# Altium schematic sheet Security_module_v2_sheet.SchDoc
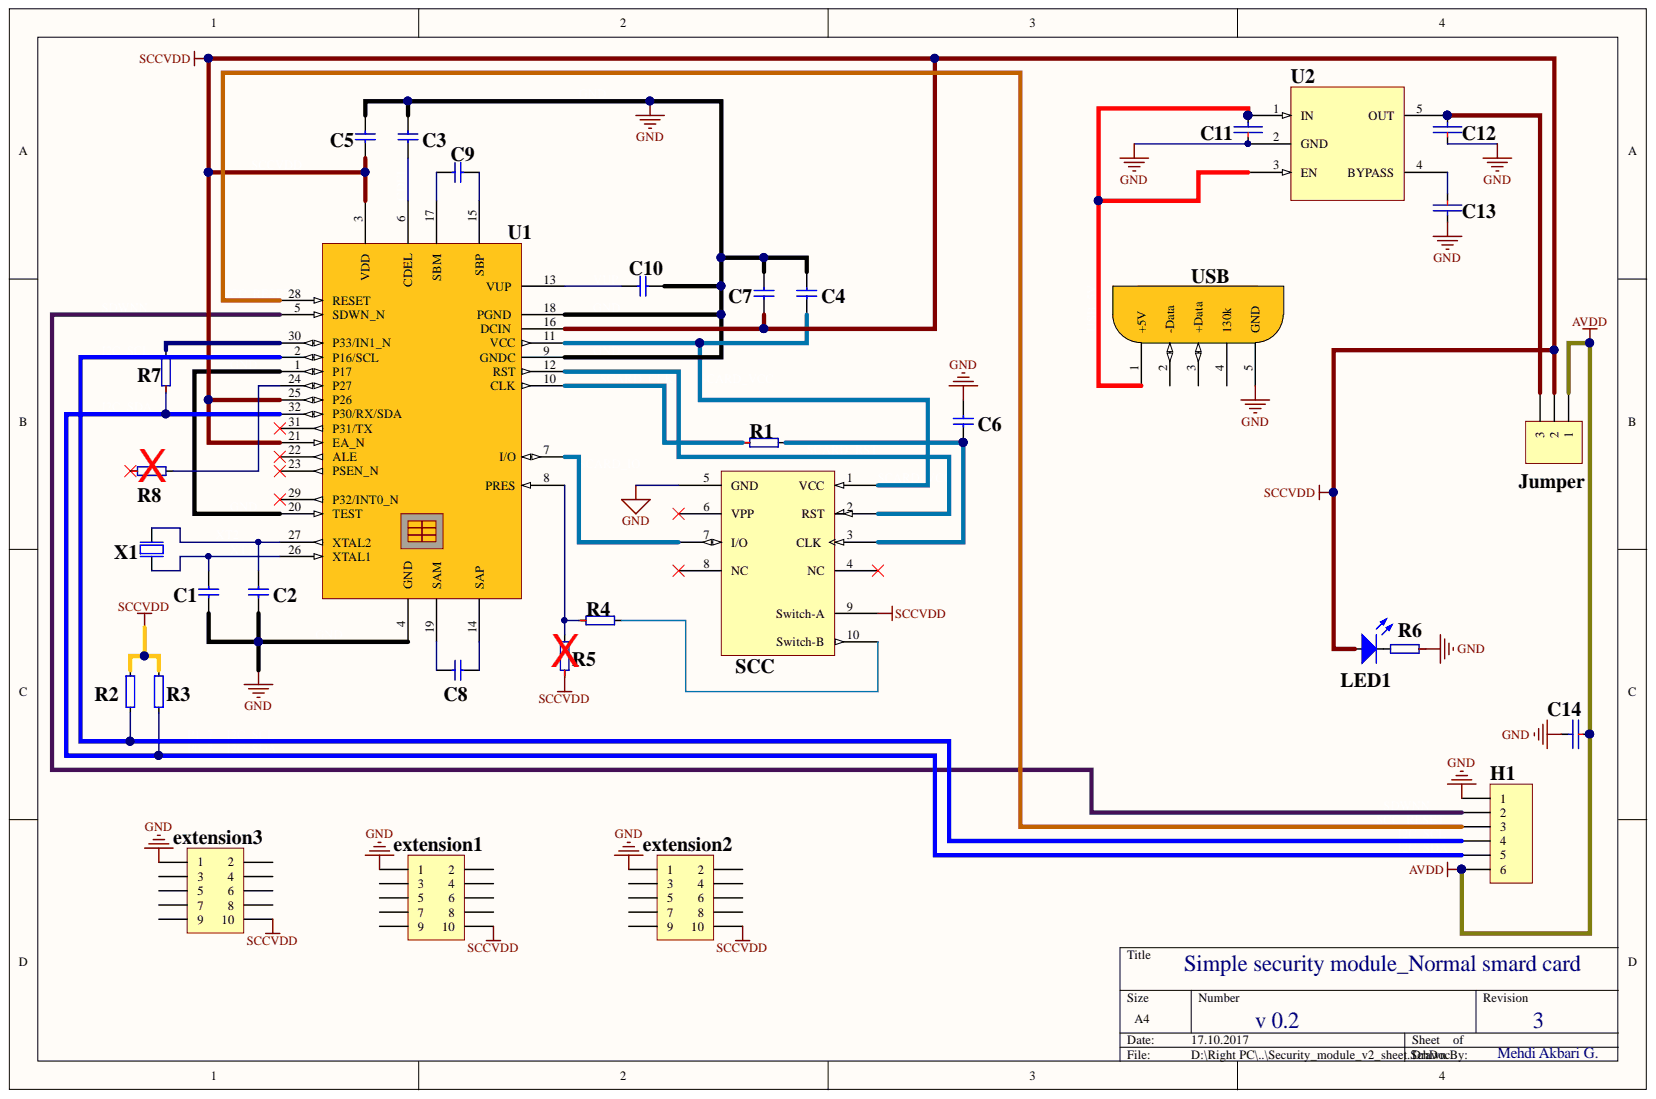
[diagram p0: Security_module_v2_sheet.SchDoc]

## Parts
C1  22pF  (value not drawn)
C2  22pF  (value not drawn)
C3  22nF  (value not drawn)
C4  220nF  (value not drawn)
C5  100nF  (value not drawn)
C6  33pF  (value not drawn)
C7  100nF  (value not drawn)
C8  220nF  (value not drawn)
C9  220nF  (value not drawn)
C10  220nF  (value not drawn)
C11  C_10U_0603_X5R_K_10  [CAP_IMPERIAL_0603]  (value not drawn)
C12  C_4U7_0603_X5R_K_6  [CAP_IMPERIAL_0603]  (value not drawn)
C13  C_10U_0603_X5R_K_10  [CAP_IMPERIAL_0603]  (value not drawn)
C14  C_10U_0603_X5R_K_10  [CAP_IMPERIAL_0603]  (value not drawn)
extension1  MHDR2X5  [extension 2X5]  (value not drawn)  (no BOM)
extension2  MHDR2X5  [extension 2X5]  (value not drawn)  (no BOM)
extension3  MHDR2X5  [extension 2X5]  (value not drawn)  (no BOM)
H1  Header  [HDR1X6]  (value not drawn)
Jumper  Jumper  [HDR1X3]
LED1  BLUE_LED_0603_2.1V_10mA_6mcd  [LED_IMPERIAL_0603_BLUE]  (value not drawn)
R1  R_100_0603_F  [RES_IMPERIAL_0603]  (value not drawn)
R2  10k  (value not drawn)
R3  10k  [0402]  (value not drawn)
R4  R_100_0603_F  [RES_IMPERIAL_0603]  (value not drawn)
R5  R_0_0603_F  [RES_IMPERIAL_0603]  (value not drawn)  (no BOM)
R6  R_1K_0603_F  [RES_IMPERIAL_0603]  (value not drawn)
R7  R_0_0603_F  [RES_IMPERIAL_0603]  (value not drawn)
R8  R_0_0603_F  [RES_IMPERIAL_0603]  (value not drawn)  (no BOM)
SCC  Smart Card Connector  [Big_smart_card_connector]  (value not drawn)
U1  TDA8029HL  [SOT358_L]  (value not drawn)
U2  LP3985IM5-3.3-NOPB  [SOT23-5AN]  (value not drawn)
USB  Micro-USB Receptacle  [M105017-0001]  (value not drawn)
X1  14.7456MHz  [BT-XTAL_3225]  (value not drawn)

## Nets
AVDD: C14.1 H1.6 Jumper.1
CDEL: C3.1 U1.6(CDEL)
GND: C1.1 C2.1 C3.2 C4.2 C5.2 C6.1 C7.2 C10.1 C11.1 C12.1 C13.2 C14.2 extension1.1 extension2.1 extension3.1 H1.1 R6.1 SCC.5(GND) U1.1(P17) U1.4(GND) U1.9(GNDC) U1.18(PGND) U1.20(TEST) U2.2(GND) USB.5(GND) X1.2 X1.4
I2C_SCL: H1.4 R2.1 U1.2(P16/SCL)
I2C_SDA: H1.5 R3.1 R7.1 U1.32(P30/RX/SDA)
SCARD_CLK: R1.1 U1.10(CLK)
SCARD_IO: SCC.7(I/O) U1.7(I/O)
SCARD_RST: SCC.2(RST) U1.12(RST)
SCARD_VCC: C4.1 SCC.1(VCC) U1.11(VCC)
SCC_RESET: H1.3 U1.28(RESET)
SCCVDD: C5.1 C7.1 extension1.10 extension2.10 extension3.10 Jumper.2 LED1.1 R2.2 R3.2 R5.1 SCC.9(Switch-A) U1.3(VDD) U1.16(DCIN) U1.21(EA_N) U1.25(P26)
SDWNN: H1.2 U1.5(SDWN_N)
USB_5V: C11.2 U2.1(IN) U2.3(EN) USB.1(+5V)
VUP: C10.2 U1.13(VUP)
XT1: C1.2 U1.26(XTAL1) X1.3
XT2: C2.2 U1.27(XTAL2) X1.1
NetC6_2: C6.2 R1.2 SCC.3(CLK)
NetC8_1: C8.1 U1.19(SAM)
NetC8_2: C8.2 U1.14(SAP)
NetC9_1: C9.1 U1.17(SBM)
NetC9_2: C9.2 U1.15(SBP)
NetC12_2: C12.2 Jumper.3 U2.5(OUT)
NetC13_1: C13.1 U2.4(BYPASS)
NetLED1_2: LED1.2 R6.2
NetR4_1: R4.1 R5.2 U1.8(PRES)
NetR4_2: R4.2 SCC.10(Switch-B)
NetR7_2: R7.2 U1.30(P33/IN1_N)
NetR8_2: R8.2 U1.24(P27)

Not connected: extension1 2 3 4 5 6 7 8 9; extension2 2 3 4 5 6 7 8 9; extension3 2 3 4 5 6 7 8 9; R8 1; SCC 4(NC) 6(VPP) 8(NC); U1 22(ALE) 23(PSEN_N) 29(P32/INT0_N) 31(P31/TX); USB 2(-Data) 3(+Data) 4(130k)

## Library data of the parts
C1 C2 = (no symbol name): Capacitor, 22p, 0603, NPO, 10%, 16V; Component Kind: Standard; Pin Count: 2; Library Name: UNICEPT.SchLib; Publisher: UNICEPT GmbH
  C1: Value: 22pF
C3 = (no symbol name): Capacitor, 22n, 0603, X5R, 10%, 16V; Note: 6.3V; Component Kind: Standard; Pin Count: 2; Library Name: UNICEPT.SchLib; Publisher: UNICEPT GmbH
C4 C8-C10 = (no symbol name): Capacitor, 220n, 0603, X5R, 10%, 16V; Component Kind: Standard; Pin Count: 2; Library Name: UNICEPT.SchLib; Publisher: UNICEPT GmbH
C5 = (no symbol name): Capacitor, 100n, 0603, X5R, 10%, 16V; Component Kind: Standard; Pin Count: 2; Library Name: UNICEPT.SchLib; Publisher: UNICEPT GmbH
C6 = (no symbol name): Capacitor, 33p, 0603, NPO, 10%, 16V; Note: 6.3V; Component Kind: Standard; Pin Count: 2; Library Name: UNICEPT.SchLib; Publisher: UNICEPT GmbH
C7 = (no symbol name): Capacitor, 100n, 0603, X5R, 10%, 16V; Note: 6.3V; Component Kind: Standard; Pin Count: 2; Library Name: UNICEPT.SchLib; Publisher: UNICEPT GmbH
C11 = C1608X5R1A106K080AC: Capacitor, 10u, 0603, X5R, 10%, 10V; Component Kind: Standard; Library Name: CustomComponentLibrary.IntLib
C12 = GRM188R60J475KE19D: Capacitor, 4u7, 0603 X5R, 10%, 6.3V; Component Kind: Standard; Library Name: CustomComponentLibrary.IntLib
C13 C14 = C1608X5R0J226M080AC: Capacitor, 10u, 0603, X5R, 10%, 10V; Component Kind: Standard; Library Name: CustomComponentLibrary.IntLib
extension1-extension3 = MHDR2X5: Header, 5-Pin, Dual row; LatestRevisionDate: 17-Jul-2002; LatestRevisionNote: Re-released for DXP Platform.; Library Name: Miscellaneous Connectors.IntLib; Signal Integrity: Connector; Publisher: Altium Limited
H1 = Header 6: Header, 6-Pin; Component Kind: Standard; LatestRevisionDate: 17-Jul-2002; LatestRevisionNote: Re-released for DXP Platform.; Library Name: Miscellaneous Connectors.IntLib; Publisher: Altium Limited
Jumper = Header 3: Header, 3-Pin; Component Kind: Standard; LatestRevisionDate: 17-Jul-2002; LatestRevisionNote: Re-released for DXP Platform.; Library Name: Miscellaneous Connectors.IntLib; Signal Integrity: Connector; Publisher: Altium Limited
LED1 = LTST-C193TBKT-5A: Blue LED, 0603, 2.1V, 10mA, 6mcd; Component Kind: Standard; Library Name: CustomComponentLibrary.IntLib
R1 R4 = RK73H1JTTD1002F: Resistor, 100 ohms, 0603, 1%; Manufacturer: KOA Speer; Value: 10k; Component Kind: Standard; Library Name: CustomComponentLibrary.IntLib
R2 R3 = (no symbol name): Resistor, 10k ohms, 0603, 1%, 0.063W; Part Number: R0402; Note: Chip Res 1%; Component Kind: Standard; Pin Count: 2; Library Name: UNICEPT.SchLib; Publisher: UNICEPT GmbH
R5 R7 R8 = RK73H1ETTP33R0F: Resistor, 0 ohm, 0.063W 0603, 1%; Manufacturer: Yageo; Value: 0; Library Name: CustomComponentLibrary.IntLib
  R7: Component Kind: Standard
R6 = RK73H1JTTD1001F: Resistor, 1k ohms, 0603, 1%; Manufacturer: KOA Speer; Component Kind: Standard; Library Name: CustomComponentLibrary.IntLib; Value: 1k
SCC = Smart_card_connector: Smartcard Connector with Switch; Component Kind: Standard; Library Name: Smartcard Connector with Switch.SchLib
U1 = TDA8029HL: Smart Card Reader IC, SOT358; Component Kind: Standard; Package description: LPQF32, 0.8 mm pitch; Library Name: UNICEPT.SchLib
U2 = LP3985IM5-3.3-NOPB: Micropower, 3.3V, 150mA Low-Noise Ultra Low-Dropout CMOS Voltage Regulator; Component Kind: Standard; Library Name: CustomComponentLibrary.IntLib
USB = Micro-USB Receptacle: M105017-0001; Component Kind: Standard; Library Name: Micro-USB Receptacle.SchLib
X1 = (no symbol name): XTAL SMD 3225, 18pF, -/+30ppm; 2nd source part number: 184-2056; Manufacturer: TXC Corporation; 2nd source Supplier: Farnell; Component Kind: Standard; Datasheet: http://www.txccrystal.com/images/pdf/7m.pdf; Note: XTAL SMD; Pin Count: 4; Published: 2010-08-31; Supplier: Digi-Key; Supplier part number: 887-1121-1-ND; Library Name: UNICEPT.SchLib; Publisher: UNICEPT GmbH
